# Altium schematic sheet Sheet1.SchDoc
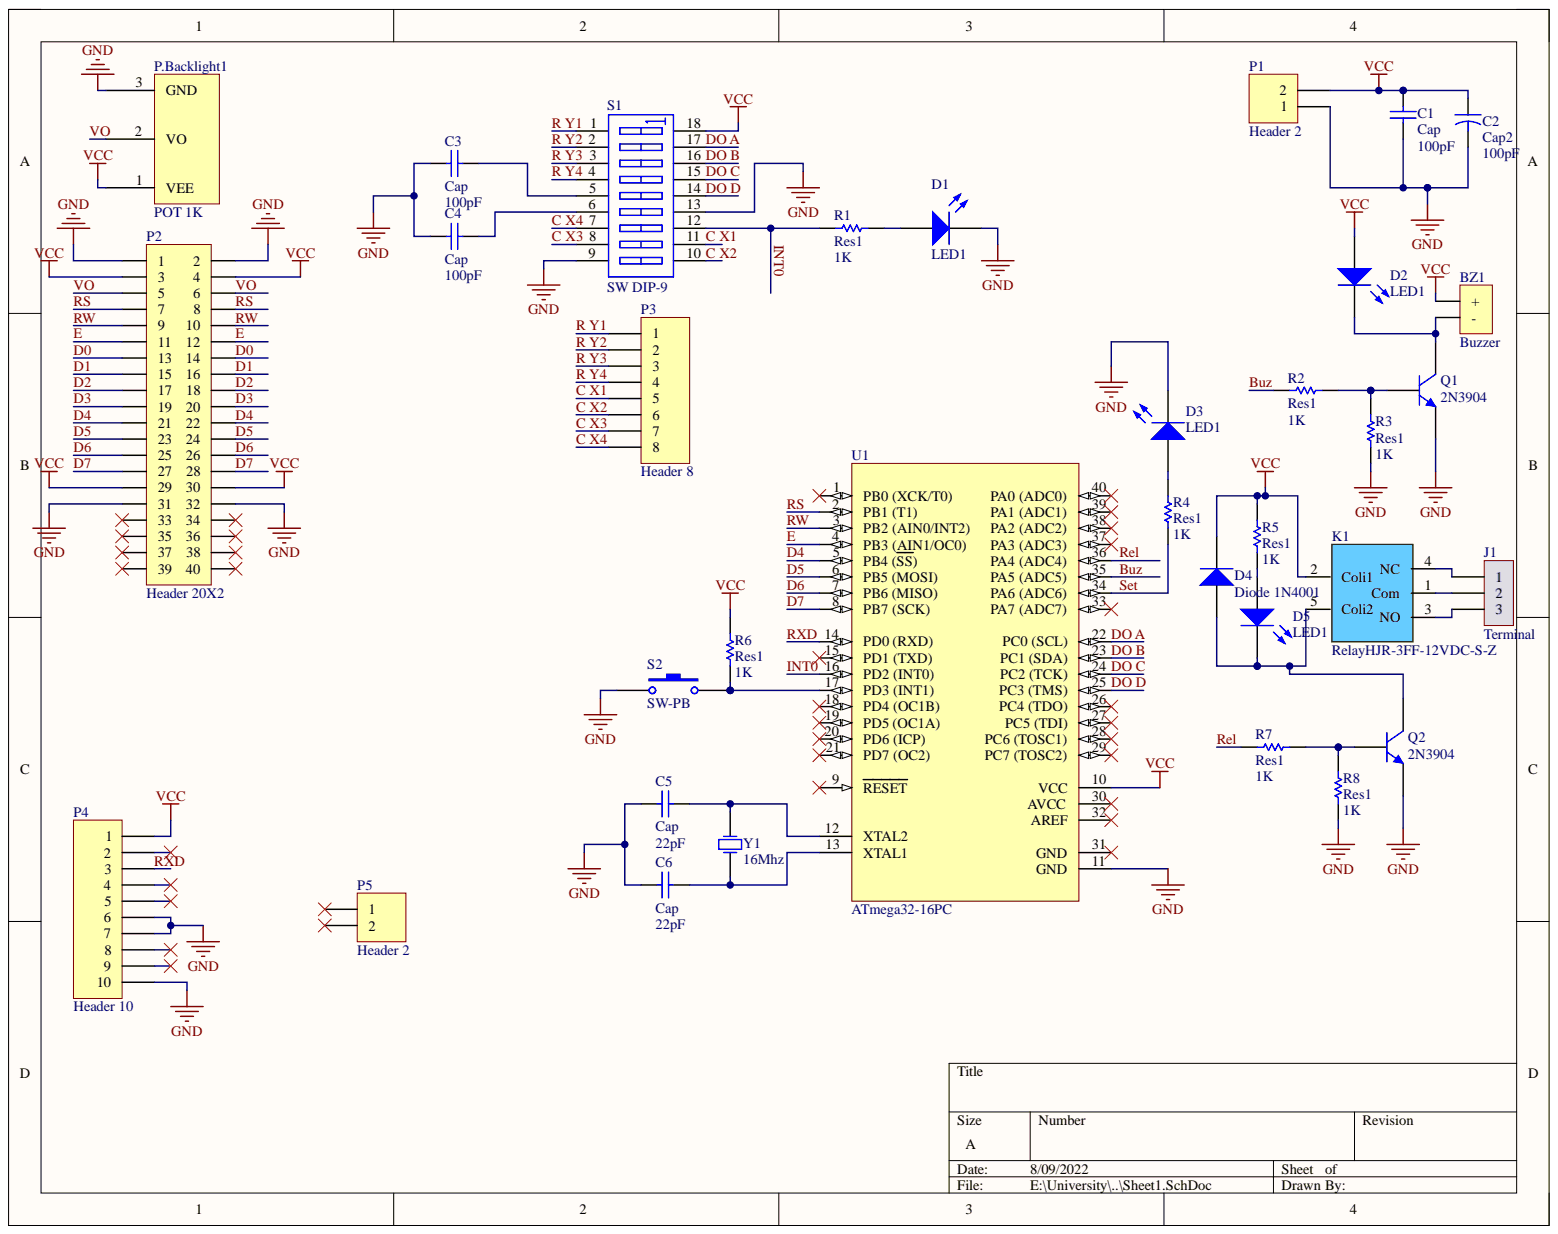
[diagram p0: Sheet1.SchDoc]

## Parts
BZ1  Buzzer  [Buzzer_Big]
C1  Cap  value 100pF  [RAD-0.3]
C2  Cap2  value 100pF  [CAPR5-4X5]
C3  Cap  value 100pF  [RAD-0.3]
C4  Cap  value 100pF  [RAD-0.3]
C5  Cap  value 22pF  [RAD-0.3]
C6  Cap  value 22pF  [RAD-0.3]
D1  LED1  [LED-1]
D2  LED1  [LED-1]
D3  LED1  [LED-1]
D4  Diode 1N4001  [DIO10.46-5.3x2.8]
D5  LED1  [LED-1]
J1  Terminal  [TERMINAL3]
K1  RelayHJR-3FF-12VDC-S-Z  [HJR-3FF-12VDC-S-Z]
P1  Header 2  [HDR1X2]
P2  Header 20X2  [Header2x20]
P3  Header 8  [HDR1X8]
P4  Header 10  [HDR1X10]
P5  Header 2  [HDR1X2]
P.Backlight1  POT 1K  [POT10]
Q1  2N3904  [BCY-W3/E4]
Q2  2N3904  [BCY-W3/E4]
R1  Res1  value 1K  [AXIAL-0.3]
R2  Res1  value 1K  [AXIAL-0.3]
R3  Res1  value 1K  [AXIAL-0.3]
R4  Res1  value 1K  [AXIAL-0.3]
R5  Res1  value 1K  [AXIAL-0.3]
R6  Res1  value 1K  [AXIAL-0.3]
R7  Res1  value 1K  [AXIAL-0.3]
R8  Res1  value 1K  [AXIAL-0.3]
S1  SW DIP-9  [DIP-18]
S2  SW-PB  [SPST-2]
U1  ATmega32-16PC  [40P6]
Y1  16Mhz  [Crystal]

## Nets
GND: C1.1 C2.2 C3.1 C4.1 C5.1 C6.1 D1.2 D3.2 P1.1 P2.1 P2.2 P2.31 P2.32 P4.6 P4.7 P4.10 P.Backlight1.3 Q1.1 Q2.1 R3.2 R8.2 S1.9 S1.13 S2.1 U1.11(GND)
VCC: BZ1.1 C1.2 C2.1 D2.1 D4.2 K1.2(Coli1) P1.2 P2.3 P2.4 P2.29 P2.30 P4.1 P.Backlight1.1 R5.1 R6.2 S1.18 U1.10(VCC)
Buz: R2.2 U1.35(PA5_(ADC5))
C X1: P3.5 S1.11
C X2: P3.6 S1.10
C X3: P3.7 S1.8
C X4: P3.8 S1.7
D0: P2.13 P2.14
D1: P2.15 P2.16
D2: P2.17 P2.18
D3: P2.19 P2.20
D4: P2.21 P2.22 U1.5(PB4_(SS))
D5: P2.23 P2.24 U1.6(PB5_(MOSI))
D6: P2.25 P2.26 U1.7(PB6_(MISO))
D7: P2.27 P2.28 U1.8(PB7_(SCK))
DO A: S1.17 U1.22(PC0_(SCL))
DO B: S1.16 U1.23(PC1_(SDA))
DO C: S1.15 U1.24(PC2_(TCK))
DO D: S1.14 U1.25(PC3_(TMS))
E: P2.11 P2.12 U1.4(PB3_(AIN1/OC0))
INT0: R1.1 S1.12 U1.16(PD2_(INT0))
R Y1: P3.1 S1.1
R Y2: P3.2 S1.2
R Y3: P3.3 S1.3
R Y4: P3.4 S1.4
Rel: R7.2 U1.36(PA4_(ADC4))
RS: P2.7 P2.8 U1.2(PB1_(T1))
RW: P2.9 P2.10 U1.3(PB2_(AIN0/INT2))
RXD: P4.3 U1.14(PD0_(RXD))
Set: R4.2 U1.34(PA6_(ADC6))
VO: P2.5 P2.6 P.Backlight1.2
NetBZ1_2: BZ1.2 D2.2 Q1.3
NetC3_2: C3.2 S1.5
NetC4_2: C4.2 S1.6
NetC5_2: C5.2 U1.12(XTAL2) Y1.2
NetC6_2: C6.2 U1.13(XTAL1) Y1.1
NetD1_1: D1.1 R1.2
NetD3_1: D3.1 R4.1
NetD4_1: D4.1 D5.2 K1.5(Coli2) Q2.3
NetD5_1: D5.1 R5.2
NetJ1_1: J1.1 K1.4(NC)
NetJ1_2: J1.2 K1.1(Com)
NetJ1_3: J1.3 K1.3(NO)
NetQ1_2: Q1.2 R2.1 R3.1
NetQ2_2: Q2.2 R7.1 R8.1
NetR6_1: R6.1 S2.2 U1.17(PD3_(INT1))

Not connected: P2 33 34 35 36 37 38 39 40; P4 2 4 5 8 9; P5 1 2; U1 1(PB0_(XCK/T0)) 9(RESET) 15(PD1_(TXD)) 18(PD4_(OC1B)) 19(PD5_(OC1A)) 20(PD6_(ICP)) 21(PD7_(OC2)) 26(PC4_(TDO)) 27(PC5_(TDI)) 28(PC6_(TOSC1)) 29(PC7_(TOSC2)) 30(AVCC) 31(GND) 32(AREF) 33(PA7_(ADC7)) 37(PA3_(ADC3)) 38(PA2_(ADC2)) 39(PA1_(ADC1)) 40(PA0_(ADC0))

## Library data of the parts
BZ1 = Buzzer: Buzzer 6v to 24 v; Component Kind: Standard; Library Name: Sajad_Altium_Library.SchLib
C1 C4 C6 = Cap: Capacitor; Component Kind: Standard; LatestRevisionDate: 17-Jul-2002; LatestRevisionNote: Re-released for DXP Platform.; Library Name: Miscellaneous Devices.IntLib; PackageReference: RAD-0.3; Published: 8-Jun-2000; Signal Integrity: Cap; Simulation: CAP; Publisher: Altium Limited
C2 = Cap2: Capacitor; Component Kind: Standard; Library Name: Miscellaneous Devices.IntLib; PackageReference: CAPR5-4X5; Published: 23-Sep-2002; Signal Integrity: Cap; Simulation: CAP; Publisher: Altium Limited
C3 = Cap: Capacitor; Value: 100pF; Component Kind: Standard; LatestRevisionDate: 17-Jul-2002; LatestRevisionNote: Re-released for DXP Platform.; Library Name: Miscellaneous Devices.IntLib; PackageReference: RAD-0.3; Published: 8-Jun-2000; Signal Integrity: Cap; Simulation: CAP; Publisher: Altium Limited
C5 = Cap: Capacitor; Value: 22pF; Component Kind: Standard; LatestRevisionDate: 17-Jul-2002; LatestRevisionNote: Re-released for DXP Platform.; Library Name: Miscellaneous Devices.IntLib; PackageReference: RAD-0.3; Published: 8-Jun-2000; Signal Integrity: Cap; Simulation: CAP; Publisher: Altium Limited
D1-D3 D5 = LED1: Typical RED GaAs LED; Component Kind: Standard; LatestRevisionDate: 08-Jul-2005; LatestRevisionNote: Mech Layer 1 removed.; Library Name: Miscellaneous Devices.IntLib; PackageReference: LED-1; Published: 8-Jun-2000; Simulation: LED1; Publisher: Altium Limited
D4 = Diode 1N4001: 1 Amp General Purpose Rectifier; Code_JEDEC: DO-204-AL; Component Kind: Standard; ComponentLink1Description: Manufacturer Link; ComponentLink1URL: http://www.fairchildsemi.com/; ComponentLink2Description: Datasheet; ComponentLink2URL: http://www.fairchildsemi.com/ds/1N%2F1N4001.pdf; DatasheetVersion: 1998; LatestRevisionDate: 17-Jul-2002; LatestRevisionNote: Re-released for DXP Platform.; Library Name: Miscellaneous Devices.IntLib; PackageReference: DO-41; PackageVersion: Aug-1999; Published: 8-Jun-2000; Signal Integrity: 1N4001; Simulation: 1N4001; Publisher: Altium Limited
J1 = Terminal 3 Pin: power 3 pin terminal; Component Kind: Standard; Library Name: Sajad_Altium_Library.SchLib
K1 = RelayHJR-3FF-12VDC-S-Z: HJR-3FF-12VDC-S-Z; Component Kind: Standard; Library Name: Sajad_Altium_Library.SchLib
P1 P5 = Header 2: Header, 2-Pin; Component Kind: Standard; LatestRevisionDate: 17-Jul-2002; LatestRevisionNote: Re-released for DXP Platform.; Publisher: Altium Limited
  P5: Library Name: Miscellaneous Connectors.IntLib; Signal Integrity: Connector
  P1: Library Name: Sajad_Altium_Library.SchLib
P2 = Header 20X2: Header, 20-Pin, Dual row; Component Kind: Standard; LatestRevisionDate: 17-Jul-2002; LatestRevisionNote: Re-released for DXP Platform.; Library Name: Sajad_Altium_Library.SchLib; Publisher: Altium Limited
P3 = Header 8: Header, 8-Pin; Component Kind: Standard; LatestRevisionDate: 17-Jul-2002; LatestRevisionNote: Re-released for DXP Platform.; Library Name: Miscellaneous Connectors.IntLib; Signal Integrity: Connector; Publisher: Altium Limited
P4 = Header 10: Header, 10-Pin; Component Kind: Standard; LatestRevisionDate: 17-Jul-2002; LatestRevisionNote: Re-released for DXP Platform.; Library Name: Miscellaneous Connectors.IntLib; Signal Integrity: Connector; Publisher: Altium Limited
P.Backlight1 = POT10k: Component Kind: Standard; Library Name: Sajad_Altium_Library.SchLib
Q1 Q2 = 2N3904: NPN General Purpose Amplifier; Code_JEDEC: TO-92A; Component Kind: Standard; ComponentLink1Description: Manufacturer Link; ComponentLink1URL: http://www.fairchildsemi.com/; ComponentLink2Description: Datasheet; ComponentLink2URL: http://www.fairchildsemi.com/ds/2N%2F2N3904.pdf; DatasheetVersion: 1997; LatestRevisionDate: 15-Jan-2003; LatestRevisionNote: PCB Footprint 'BCY-W3/D4.7' replaced; Library Name: Miscellaneous Devices.IntLib; PackageReference: TO-92A; PackageVersion: Sep-1998; Published: 8-Jun-2000; Signal Integrity: 2N3904; Simulation: 2N3904; Publisher: Altium Limited
R1-R8 = Res1: Resistor; Component Kind: Standard; LatestRevisionDate: 17-Jul-2002; LatestRevisionNote: Re-released for DXP Platform.; Library Name: Miscellaneous Devices.IntLib; PackageReference: AXIAL-0.3; Published: 8-Jun-2000; Signal Integrity: Res; Simulation: RESISTOR; Publisher: Altium Limited
S1 = SW DIP-9: DIP Switch, 9 Position, SPST; Code_JEDEC: MO-036-AE; Component Kind: Standard; LatestRevisionDate: 17-Jul-2002; LatestRevisionNote: Re-released for DXP Platform.; Library Name: Miscellaneous Devices.IntLib; PackageReference: DIP-18; Published: 24-Mar-1999; Simulation: dpsw9; Publisher: Altium Limited
S2 = SW-PB: Switch; Component Kind: Standard; LatestRevisionDate: 17-Jul-2002; LatestRevisionNote: Re-released for DXP Platform.; Library Name: Miscellaneous Devices.IntLib; PackageReference: SPST-2; Published: 24-Mar-1999; Publisher: Altium Limited
U1 = ATmega32-16PC: 8-Bit AVR Microcontroller with 32K Bytes of In-System Programmable Flash Memory; Component Kind: Standard; ComponentLink1Description: Manufacturer Link; ComponentLink1URL: http://www.atmel.com; ComponentLink2Description: Datasheet; ComponentLink2URL: http://www.atmel.com/dyn/resources/prod_documents/doc2503.pdf; DatasheetVersion: 2503C-AVR-10/02; LatestRevisionDate: 04-Mar-2005; LatestRevisionNote: Stylized 3D Model Added.; Library Name: Atmel Microcontroller 8-Bit AVR.IntLib; PackageDescription: DIP; 40 Leads; Row Spacing 15.24 mm; Pitch 2.54 mm; PackageReference: 40P6; PackageVersion: Dec-1999; PCB3D: 40P6; Published: 17-Sep-2003; Publisher: Altium Limited
Y1 = XTAL: Crystal Oscillator; Component Kind: Standard; LatestRevisionDate: 17-Jul-2002; LatestRevisionNote: Re-released for DXP Platform.; PackageReference: R38; Published: 8-Jun-2000; Library Name: Sajad_Altium_Library.SchLib; Publisher: Altium Limited
